# Altium schematic sheet Extra1_temp_sensor.SchDoc
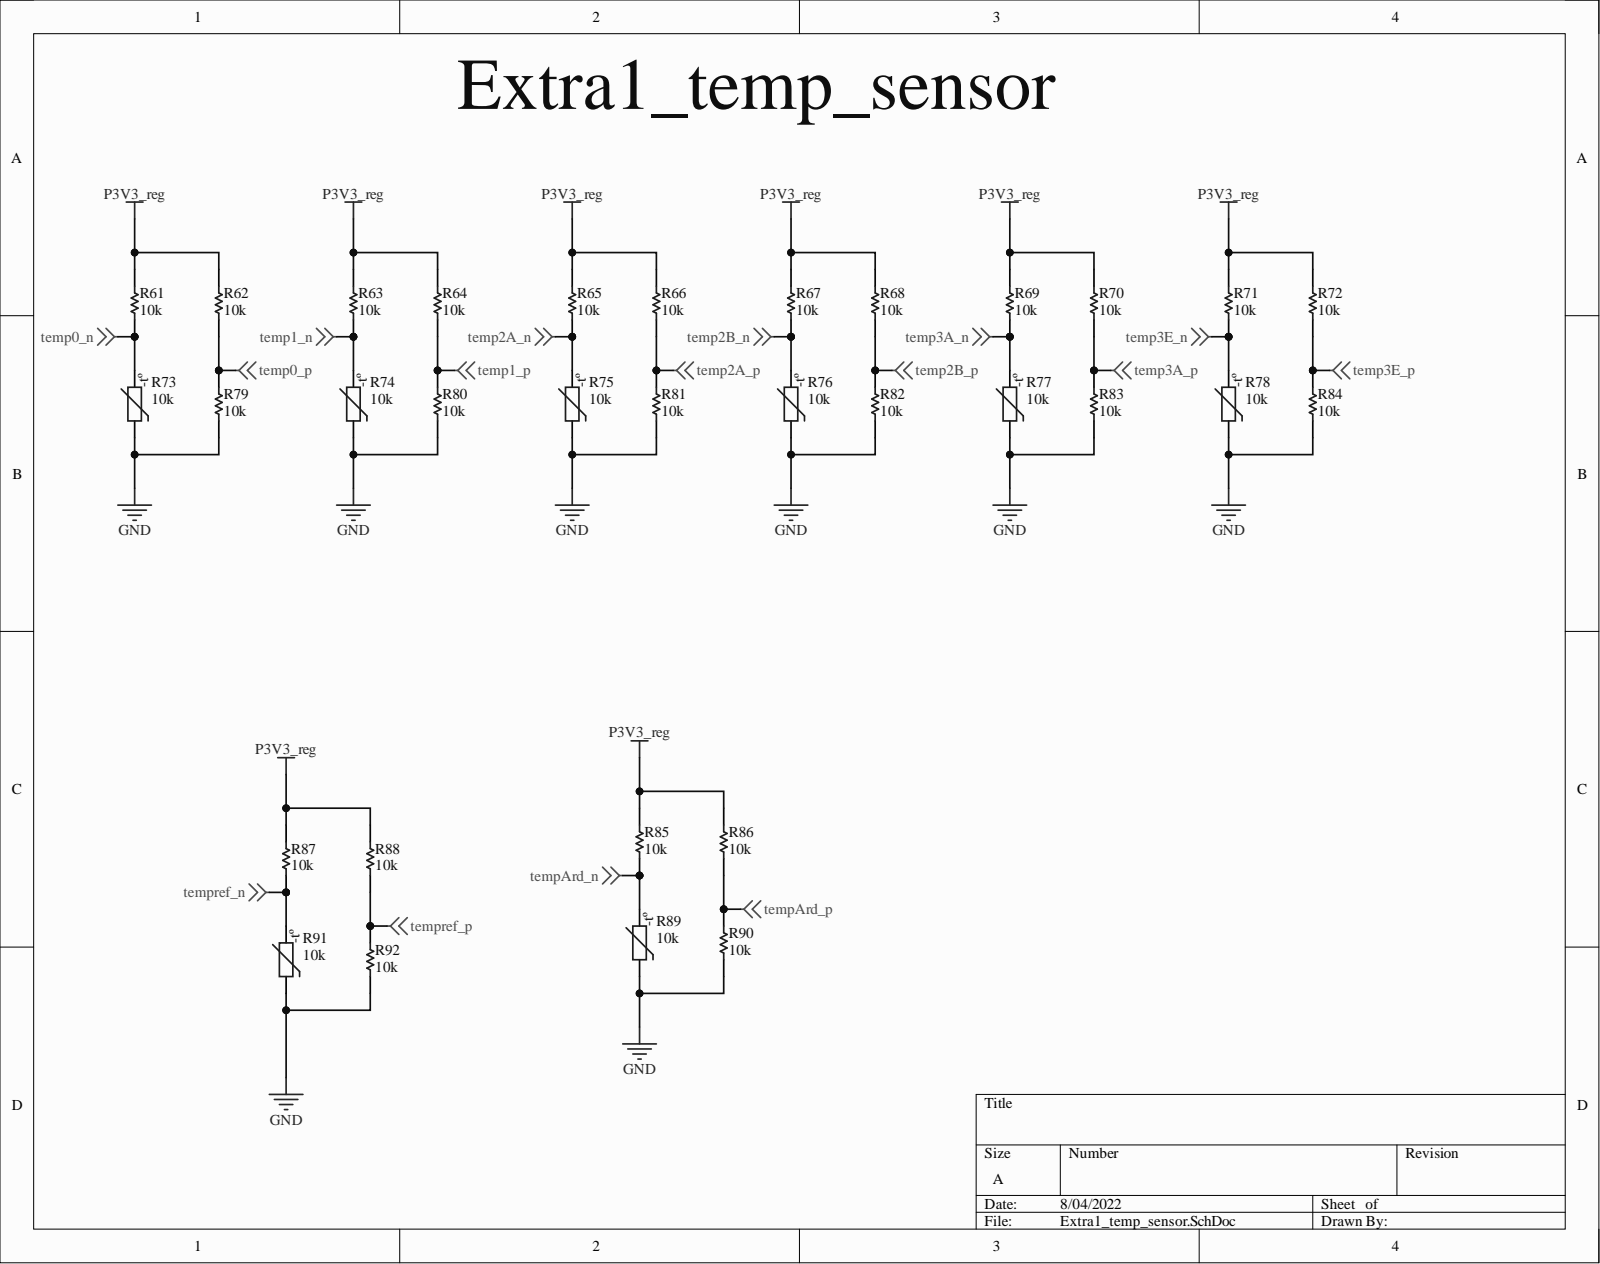
[diagram p0: Extra1_temp_sensor.SchDoc]

## Parts
R61  10k  [PCB-pyq9kh6em9qbe63wk1g2-1]
R62  10k  [PCB-pyq9kh6em9qbe63wk1g2-1]
R63  10k  [PCB-pyq9kh6em9qbe63wk1g2-1]
R64  10k  [PCB-pyq9kh6em9qbe63wk1g2-1]
R65  10k  [PCB-pyq9kh6em9qbe63wk1g2-1]
R66  10k  [PCB-pyq9kh6em9qbe63wk1g2-1]
R67  10k  [PCB-pyq9kh6em9qbe63wk1g2-1]
R68  10k  [PCB-pyq9kh6em9qbe63wk1g2-1]
R69  10k  [PCB-pyq9kh6em9qbe63wk1g2-1]
R70  10k  [PCB-pyq9kh6em9qbe63wk1g2-1]
R71  10k  [PCB-pyq9kh6em9qbe63wk1g2-1]
R72  10k  [PCB-pyq9kh6em9qbe63wk1g2-1]
R73  10k  [PCB-ql2x737znrfhil0t0v5a-1]
R74  10k  [PCB-ql2x737znrfhil0t0v5a-1]
R75  10k  [PCB-ql2x737znrfhil0t0v5a-1]
R76  10k  [PCB-ql2x737znrfhil0t0v5a-1]
R77  10k  [PCB-ql2x737znrfhil0t0v5a-1]
R78  10k  [PCB-ql2x737znrfhil0t0v5a-1]
R79  10k  [PCB-pyq9kh6em9qbe63wk1g2-1]
R80  10k  [PCB-pyq9kh6em9qbe63wk1g2-1]
R81  10k  [PCB-pyq9kh6em9qbe63wk1g2-1]
R82  10k  [PCB-pyq9kh6em9qbe63wk1g2-1]
R83  10k  [PCB-pyq9kh6em9qbe63wk1g2-1]
R84  10k  [PCB-pyq9kh6em9qbe63wk1g2-1]
R85  10k  [PCB-pyq9kh6em9qbe63wk1g2-1]
R86  10k  [PCB-pyq9kh6em9qbe63wk1g2-1]
R87  10k  [PCB-pyq9kh6em9qbe63wk1g2-1]
R88  10k  [PCB-pyq9kh6em9qbe63wk1g2-1]
R89  10k  [PCB-ql2x737znrfhil0t0v5a-1]
R90  10k  [PCB-pyq9kh6em9qbe63wk1g2-1]
R91  10k  [PCB-ql2x737znrfhil0t0v5a-1]
R92  10k  [PCB-pyq9kh6em9qbe63wk1g2-1]

## Nets
GND: R73.1 R74.1 R75.1 R76.1 R77.1 R78.1 R79.1 R80.1 R81.1 R82.1 R83.1 R84.1 R89.1 R90.1 R91.1 R92.1
P3V3_reg: R61.2 R62.2 R63.2 R64.2 R65.2 R66.2 R67.2 R68.2 R69.2 R70.2 R71.2 R72.2 R85.2 R86.2 R87.2 R88.2
temp0_n: R61.1 R73.2
temp0_p: R62.1 R79.2
temp1_n: R63.1 R74.2
temp1_p: R64.1 R80.2
temp2A_n: R65.1 R75.2
temp2A_p: R66.1 R81.2
temp2B_n: R67.1 R76.2
temp2B_p: R68.1 R82.2
temp3A_n: R69.1 R77.2
temp3A_p: R70.1 R83.2
temp3E_n: R71.1 R78.2
temp3E_p: R72.1 R84.2
tempArd_n: R85.1 R89.2
tempArd_p: R86.1 R90.2
tempref_n: R87.1 R91.2
tempref_p: R88.1 R92.2

## Library data of the parts
R61-R72 R79-R88 R90 R92 = CMP-2e7be513b6f8f1bc-4: Res Thick Film 0805 10K Ohm 5% 1/8W ±100ppm/°C Molded SMD Paper T/R; Manufacturer Part Number: RC0805JR-0710KL; Case/Package (SI): 2012; Lead-Free Status: Lead Free; Power Rating: 125 mW; Resistance: 10.0 kΩ; Temperature Coefficient: -100 ppm/°C to 100 ppm/°C; Voltage Rating (DC): 150 V; Case/Package: 0805; Component Kind: Standard; Library Name: My Personal Space; Mounting Style: Surface Mount; Number of Pins: 2; Octopart URL: https://octopart.com/rc0805jr-0710kl-yageo-40025573; OctopartID: 2e7be513b6f8f1bc; Operating Temperature: 155 °C (max); Packaging: Tape & Reel; REACH SVHC Compliance: No SVHC; Resistance Tolerance: ±5%; RoHS: Compliant; Size-Height: 500 µm; Size-Length: 2.00 mm; Size-Width: 1.25 mm
R73-R78 R89 R91 = CMP-f88233d6fdd12745-1: Thermistor NTC 10K Ohm 5% 2-Pin 0805 Surface Mount Solder Pad 3570K -4 to -3.5 T/R Automotive; Manufacturer Part Number: NTCS0805E3103JMT; Case/Package (SI): 2012; Lead-Free Status: Lead Free; Resistance: 10.0 kΩ; Case/Package: 0805; Component Kind: Standard; Library Name: My Personal Space; Mounting Style: Surface Mount; Number of Pins: 2; Octopart URL: http://octopart.com/ntcs0805e3103jmt-vishay-39723061; OctopartID: f88233d6fdd12745; Packaging: Cut Tape (CT); REACH SVHC Compliance: No SVHC; Resistance Tolerance: ±5%; RoHS: Compliant
